# Altium schematic sheet Sheet5.SchDoc(Sheet5)
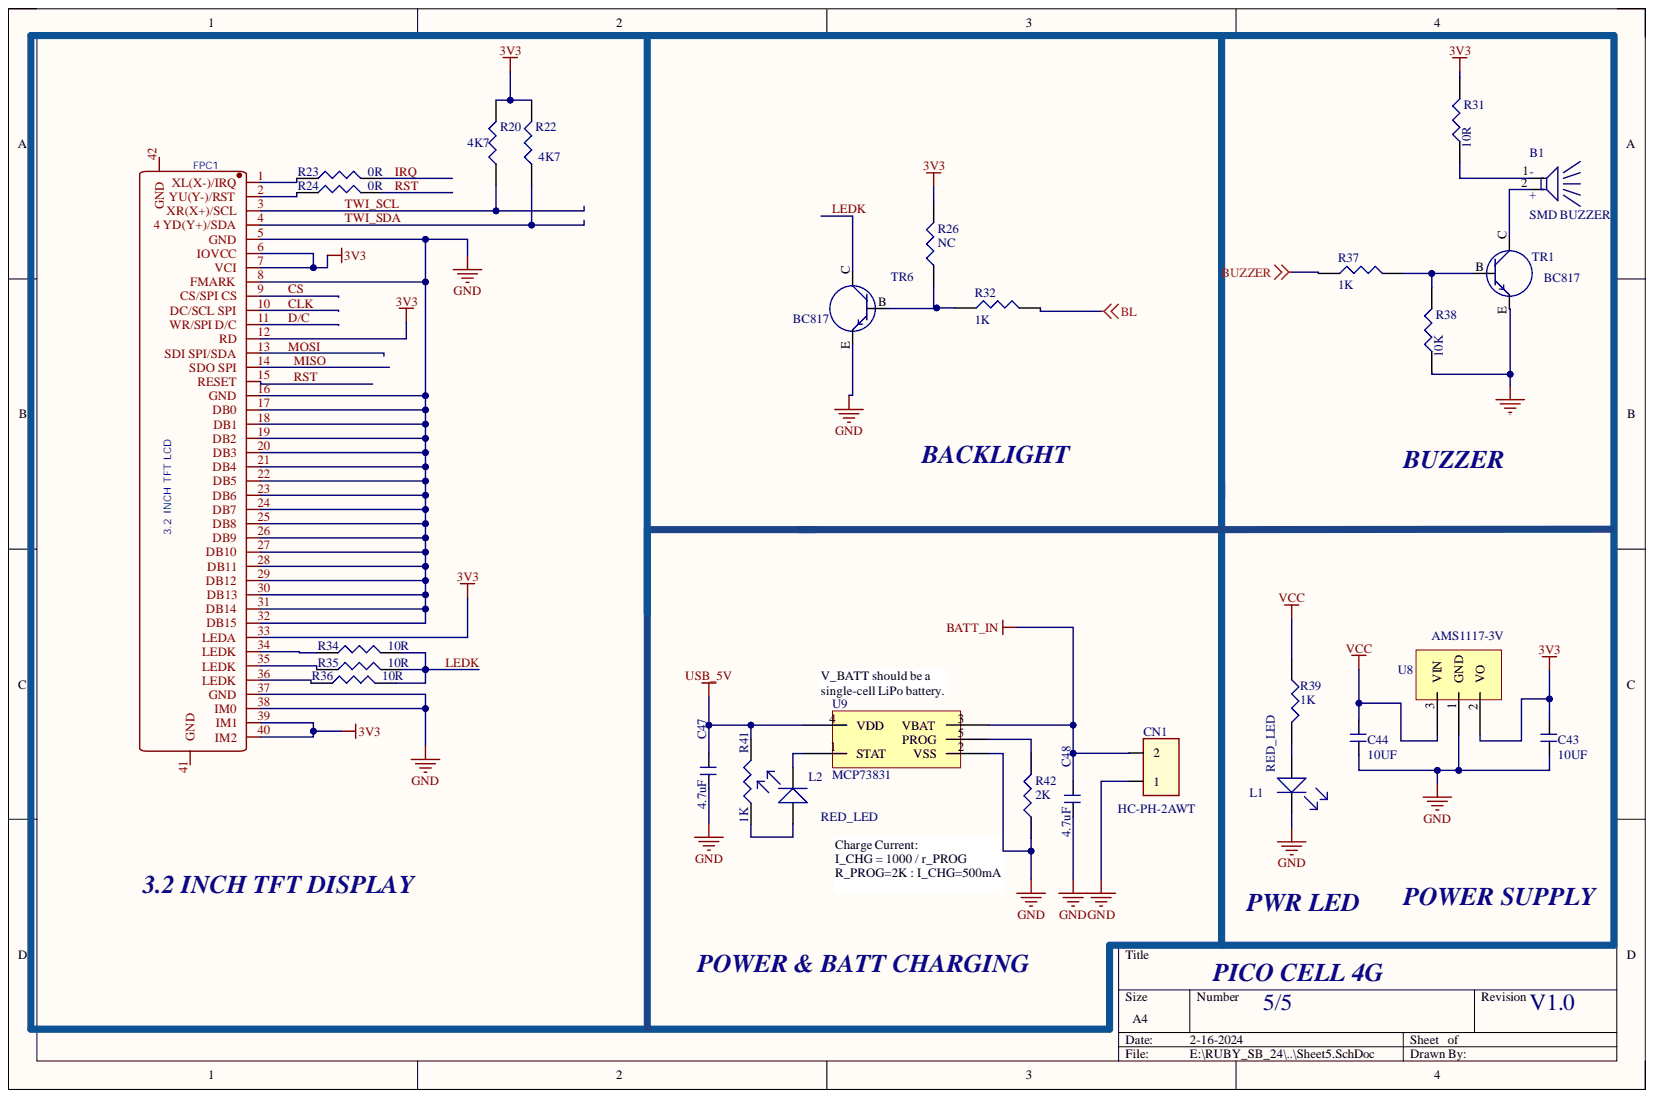
[diagram p0: Sheet5.SchDoc]

## Parts
B1  SMD BUZZER  [SMD BUZZ]
C43  10UF  [Tantlum_A_3216]
C44  10UF  [Tantlum_A_3216]
C47  4.7uF  [C_0603]
C48  4.7uF  [C_0603]
CN1  HC-PH-2AWT  [JST2P_P2.0mm]
FPC1  3.2 INCH TFT LCD  [3.2 Inch touch bottom pmt]
L1  RED_LED  [LED_0603]
L2  RED_LED  [LED_0603]
R20  4K7  [R_0603]
R22  4K7  [R_0603]
R23  0R  [R_0603]
R24  0R  [R_0603]
R26  NC  [R_0603]
R31  10R  [R_0603]
R32  1K  [R_0603]
R34  10R  [R_0603]
R35  10R  [R_0603]
R36  10R  [R_0603]
R37  1K  [R_0603]
R38  10K  [R_0603]
R39  1K  [R_0603]
R41  1K  [R_0603]
R42  2K  [R_0603]
TR1  BC817  [SOT23]
TR6  BC817  [SOT23]
U8  AMS1117-3V  [AMS1117_3V3]
U9  MCP73831  [SOT23-5]

## Nets
3V3: C43.1 FPC1.6(IOVCC) FPC1.7(VCI) FPC1.12(RD) FPC1.33(LEDA) FPC1.39(IM1) FPC1.40(IM2) R20.2 R22.2 R26.1 R31.2 U8.2
BATT_IN: C48.1 CN1.2 U9.3(VBAT)
BL: R32.1
BUZZER: R37.1
GND: C43.2 C44.2 C47.2 C48.2 CN1.1 FPC1.5(GND) FPC1.8(FMARK) FPC1.16(GND) FPC1.17(DB0) FPC1.18(DB1) FPC1.19(DB2) FPC1.20(DB3) FPC1.21(DB4) FPC1.22(DB5) FPC1.23(DB6) FPC1.24(DB7) FPC1.25(DB8) FPC1.26(DB9) FPC1.27(DB10) FPC1.28(DB11) FPC1.29(DB12) FPC1.30(DB13) FPC1.31(DB14) FPC1.32(DB15) FPC1.37(GND) FPC1.38(IM0) L1.K R38.1 R42.1 TR1.E TR6.E U8.1 U9.2(VSS)
USB_5V: C47.1 R41.2 U9.4(VDD)
VCC: C44.1 R39.1 U8.3
CLK: FPC1.10(DC/SCL_SPI)
CS: FPC1.9(CS/SPI_CS)
D/C: FPC1.11(WR/SPI_D/C)
IRQ: R23.2
LEDK: R34.2 R35.2 R36.2 TR6.C
MISO: FPC1.14(SDO_SPI)
MOSI: FPC1.13(SDI_SPI/SDA)
RST: FPC1.15(RESET) R24.2
TWI_SCL: FPC1.3(XR(X+)/SCL) R20.1
TWI_SDA: FPC1.4(4_YD(Y+)/SDA) R22.1
NetB1_1: B1.1 R31.1
NetB1_2: B1.2 TR1.C
NetFPC1_1: FPC1.1(XL(X-)/IRQ) R23.1
NetFPC1_2: FPC1.2(YU(Y-)/RST) R24.1
NetFPC1_34: FPC1.34(LEDK) R34.1
NetFPC1_35: FPC1.35(LEDK) R35.1
NetFPC1_36: FPC1.36(LEDK) R36.1
NetL1_A: L1.A R39.2
NetL2_A: L2.A R41.1
NetL2_K: L2.K U9.1(STAT)
NetR26_2: R26.2 R32.2 TR6.B
NetR37_2: R37.2 R38.2 TR1.B
NetR42_2: R42.2 U9.5(PROG)

Not connected: FPC1 41(GND) 42(GND)

## Library data of the parts
B1 = BUZZER PIEZO: Component Kind: Standard; Library Name: dxp_pasl_lib.IntLib
C43 C44 C47 C48 = CAP_NONPOLAR: Component Kind: Standard; Library Name: dxp_pasl_lib.IntLib
CN1 = CON2: Component Kind: Standard; Library Name: dxp_pasl_lib.IntLib
FPC1 = FPC-40P: Component Kind: Standard; Library Name: MIX.SchLib
L1 L2 = LED: Component Kind: Standard; Library Name: dxp_pasl_lib.IntLib
R20 R22-R24 R26 R31 R32 R34-R39 R41 R42 = RES: Component Kind: Standard; Library Name: dxp_pasl_lib.IntLib
TR1 TR6 = TRANSISTER_NPN: Component Kind: Standard; Library Name: dxp_pasl_lib.IntLib
U8 = AMS1117: Component Kind: Standard; Library Name: dxp_pasl_lib.IntLib
U9 = MCP73831: Component Kind: Standard; Library Name: dxp_pasl_lib.IntLib
